# Altium schematic sheet mcu.SchDoc
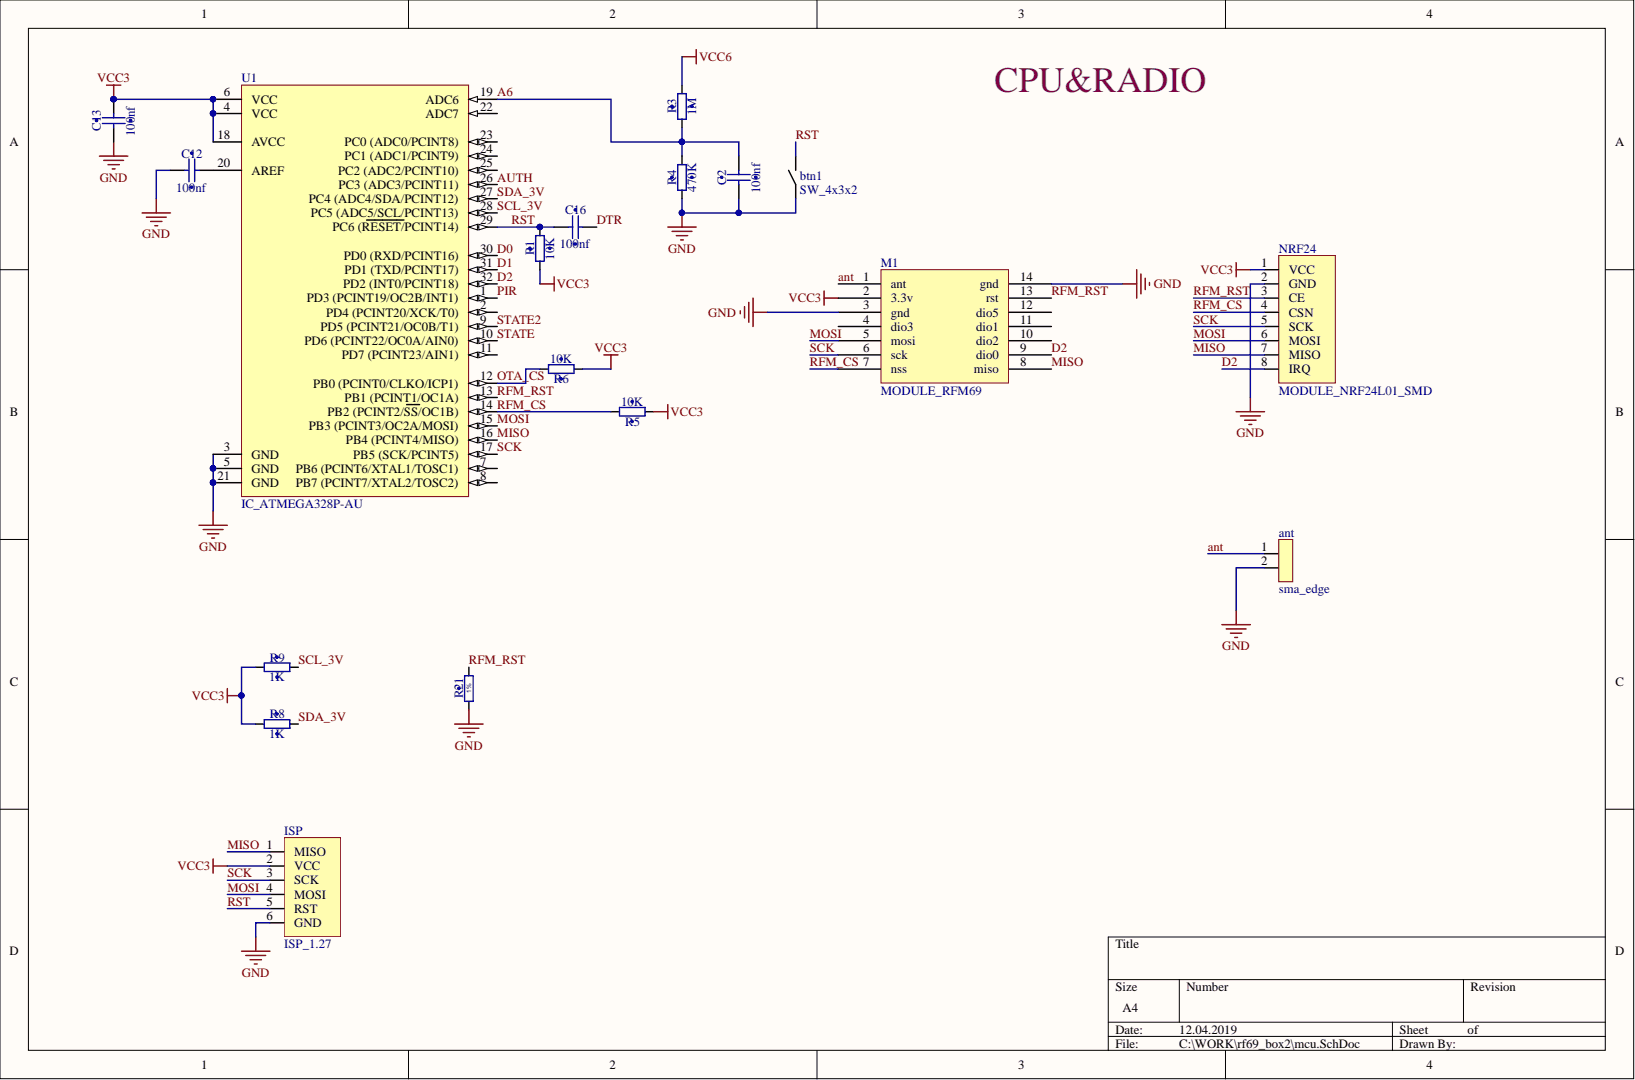
[diagram p0: mcu.SchDoc]

## Parts
ant  sma_edge  [sma_connector]
btn1  SW_4x3x2  [4x3x2_button]
C2  100nf  [CAP_0402]
C12  100nf  [CAP_0402]
C13  100nf  [CAP_0402]
C16  100nf  [CAP_0402]
ISP  ISP_1.27  [ISP_1.27]  (no BOM)
M1  MODULE_RFM69  [rf69CW_rev1.0_reset]
NRF24  MODULE_NRF24L01_SMD  [NRF24L01_SMD]
R1  10K  [RES_0402]
R3  1M  [RES_0402]
R4  470K  [RES_0402]
R5  10K  [RES_0402]
R6  10K  [RES_0402]
R8  1K  [RES_0402]
R9  1K  [RES_0402]
R21  10k  [RESC1608X55N]  (value not drawn)
U1  IC_ATMEGA328P-AU  [TQFP32]

## Nets
GND: ant.2 btn1.1 C2.1 C12.1 C13.1 ISP.6(GND) M1.3(gnd) M1.14(gnd) NRF24.2(GND) R4.1 R21.1 U1.3(GND) U1.5(GND) U1.21(GND)
VCC3: C13.2 ISP.2(VCC) M1.2(3.3v) NRF24.1(VCC) R1.1 R5.1 R6.1 R8.1 R9.1 U1.4(VCC) U1.6(VCC) U1.18(AVCC)
VCC6: R3.2
A6: C2.2 R3.1 R4.2 U1.19(ADC6)
ANT: ant.1 M1.1(ant)
AUTH: U1.26(PC3_(ADC3/PCINT11))
D0: U1.30(PD0_(RXD/PCINT16))
D1: U1.31(PD1_(TXD/PCINT17))
D2: M1.9(dio0) NRF24.8(IRQ) U1.32(PD2_(INT0/PCINT18))
DTR: C16.2
MISO: ISP.1(MISO) M1.8(miso) NRF24.7(MISO) U1.16(PB4_(PCINT4/MISO))
MOSI: ISP.4(MOSI) M1.5(mosi) NRF24.6(MOSI) U1.15(PB3_(PCINT3/OC2A/MOSI))
OTA_CS: R6.2 U1.12(PB0_(PCINT0/CLKO/ICP1))
PIR: U1.1(PD3_(PCINT19/OC2B/INT1))
RFM_CS: M1.7(nss) NRF24.4(CSN) R5.2 U1.14(PB2_(PCINT2/SS/OC1B))
RFM_RST: M1.13(rst) NRF24.3(CE) R21.2 U1.13(PB1_(PCINT1/OC1A))
RST: btn1.2 C16.1 ISP.5(RST) R1.2 U1.29(PC6_(RESET/PCINT14))
SCK: ISP.3(SCK) M1.6(sck) NRF24.5(SCK) U1.17(PB5_(SCK/PCINT5))
SCL_3V: R9.2 U1.28(PC5_(ADC5/SCL/PCINT13))
SDA_3V: R8.2 U1.27(PC4_(ADC4/SDA/PCINT12))
STATE: U1.10(PD6_(PCINT22/OC0A/AIN0))
STATE2: U1.9(PD5_(PCINT21/OC0B/T1))
NetC12_2: C12.2 U1.20(AREF)

Not connected: M1 4(dio3) 10(dio2) 11(dio1) 12(dio5); U1 2(PD4_(PCINT20/XCK/T0)) 7 8 11(PD7_(PCINT23/AIN1)) 22(ADC7) 23(PC0_(ADC0/PCINT8)) 24(PC1_(ADC1/PCINT9)) 25

## Library data of the parts
btn1 = SW_4x3x2: Database Table Name: MISC; GoodsBriefName: RC_SW_1KEY_BUTTON_SMD_3X4X2MM_2PIN; GoodsID: 59502; Value: 4x3x2_button; Код: 11; Case: 4x3x2; Component Kind: Standard; Part Number: SW_4x3x2; Pin Count: 2; Library Name: MIPS_COMPONENTS_LIB.DbLib
C2 C12 C13 C16 = C0402_100NF: Non-polarised capacitor; Database Table Name: CAP; GoodsBriefName: RC_C_0402_100NF_CC0402KRX7R7BB104_1PCS; GoodsID: 59197; Код: 1; Case: 0402; Component Kind: Standard; Part Number: C0402_100NF; Pin Count: 2; Value: 100nf; Library Name: MIPS_COMPONENTS_LIB.DbLib
M1 = MODULE_RFM69: Database Table Name: MODULES; Value: rf69CW_1.0; Код: 5; Case: board; Component Kind: Standard; Part Number: MODULE_RFM69; Pin Count: 10; Library Name: MIPS_COMPONENTS_LIB.DbLib
NRF24 = MODULE_NRF24L01_SMD: Database Table Name: MODULES; GoodsBriefName: ARDUINO_MODULE_WIRELESS_NRF24L01+_SMD; GoodsID: 53846; Код: 4; Case: board; Component Kind: Standard; Part Number: MODULE_NRF24L01_SMD; Pin Count: 8; Value: NRF24L01_SMD; Library Name: MIPS_COMPONENTS_LIB.DbLib
R1 R5 R6 = R0402_10K_1%: Resistor; Database Table Name: res; GoodsBriefName: RC_R_0402_10KOM_1PERCENT_1PCS; GoodsID: 59404; Код: 1; Case: 0402; Component Kind: Standard; Part Number: R0402_10K_1%; Pin Count: 2; Value: 10K; Library Name: MIPS_COMPONENTS_LIB.DbLib
R3 = R0402_1M_1%: Resistor; Database Table Name: res; GoodsBriefName: RC_R_0402_1MOM_1PERCENT_1PCS; GoodsID: 59836; Код: 6; Case: 0402; Component Kind: Standard; Part Number: R0402_1M_1%; Pin Count: 2; Value: 1M; Library Name: MIPS_COMPONENTS_LIB.DbLib
R4 = R0402_470K_1%: Resistor; Database Table Name: res; GoodsBriefName: RC_R_0402_470KOM_1PERCENT_1PCS; GoodsID: 59838; Код: 4; Case: 0402; Component Kind: Standard; Part Number: R0402_470K_1%; Pin Count: 2; Value: 470K; Library Name: MIPS_COMPONENTS_LIB.DbLib
R8 R9 = R0402_1K_1%: Resistor; Database Table Name: res; GoodsBriefName: RC_R_0402_1KOM_1PERCENT_1PCS; GoodsID: 59405; Код: 5; Case: 0402; Component Kind: Standard; Part Number: R0402_1K_1%; Pin Count: 2; Value: 1K; Library Name: MIPS_COMPONENTS_LIB.DbLib
R21 = R0603_10K_1%_0.1W_100PPM: Resistor - 1%; Manufacturer: GENERIC; Manufacturer1 Part Number: NRC06F1002TRF; Part Description: General Purpose Thick Film Chip Resistor; Database Table Name: Resistors SMD; Power: 0.1W; TC: ±100ppm/°C; Case: 0603; Component Kind: Standard; Component Type: Standard; CreateDate: 03.12.2007; HelpURL: \\cern.ch\dfs\Applications\Altium\Datasheets\R0603_NIC_NRC.pdf; LatestRevisionDate: 13.03.2008; Library Name: Resistors.DbLib; Manufacturer1 ComponentHeight: 0.55mm; Manufacturer1 Example: NIC COMPONENT; Manufacturer Part Number: R0603_10K_1%_0.1W_100PPM; Mounted: Yes; Part Number: R0603_10K_1%_0.1W_100PPM; Pin Count: 2; SMD: Yes; Socket: No; Status: Preferred; Table: Resistors SMD; Tolerance: ±1%; Value: 10k; Author: CERN DEM JLC
U1 = IC_ATMEGA328P-AU: 8-bit AVR Microcontroller, 32KB Flash, 1KB EEPROM, 2KB SRAM, 32-pin TQFP, Industrial Grade (-40°C to 85°C); Database Table Name: IC; GoodsBriefName: RC_IC_ATMEGA328P-AU_TQFP32; GoodsID: 50712; Код: 16; Case: TQFP32; Code_JEDEC: MS-026-ABA; Component Kind: Standard; ComponentLink1Description: Manufacturer URL; ComponentLink1URL: http://www.atmel.com/; ComponentLink2Description: ATmega48A/48PA/88A/88PA/168A/168PA/328/328P Datasheet; ComponentLink2URL: http://www.atmel.com/dyn/resources/prod_documents/doc8271.pdf; ComponentLink3Description: ATmega48A/48PA/88A/88PA/168A/168PA/328/328P Summary; ComponentLink3URL: http://www.atmel.com/dyn/resources/prod_documents/8271S.pdf; DatasheetVersion: revD, May-2011; PackageDescription: 32-Lead, 7x7x1.0 mm Body, 0.8mm Lead Pitch, Thin Profile Plastic Quad Flat Package (TQFP); PackageReference: 32A; PackageVersion: revC, Oct-2010; Part Number: IC_ATMEGA328P-AU; Pin Count: 32; Value: ATMEGA328P-AU; Library Name: MIPS_COMPONENTS_LIB.DbLib
ant = sma_edge: Component Kind: Standard; Library Name: nano_rf_shield1.SchLib
ISP = ISP_1.27: Library Name: rf69.SchLib
